# Altium schematic sheet Sheet_MotorDrive_v1.SchDoc(Sheet_MotorDrive_v1)
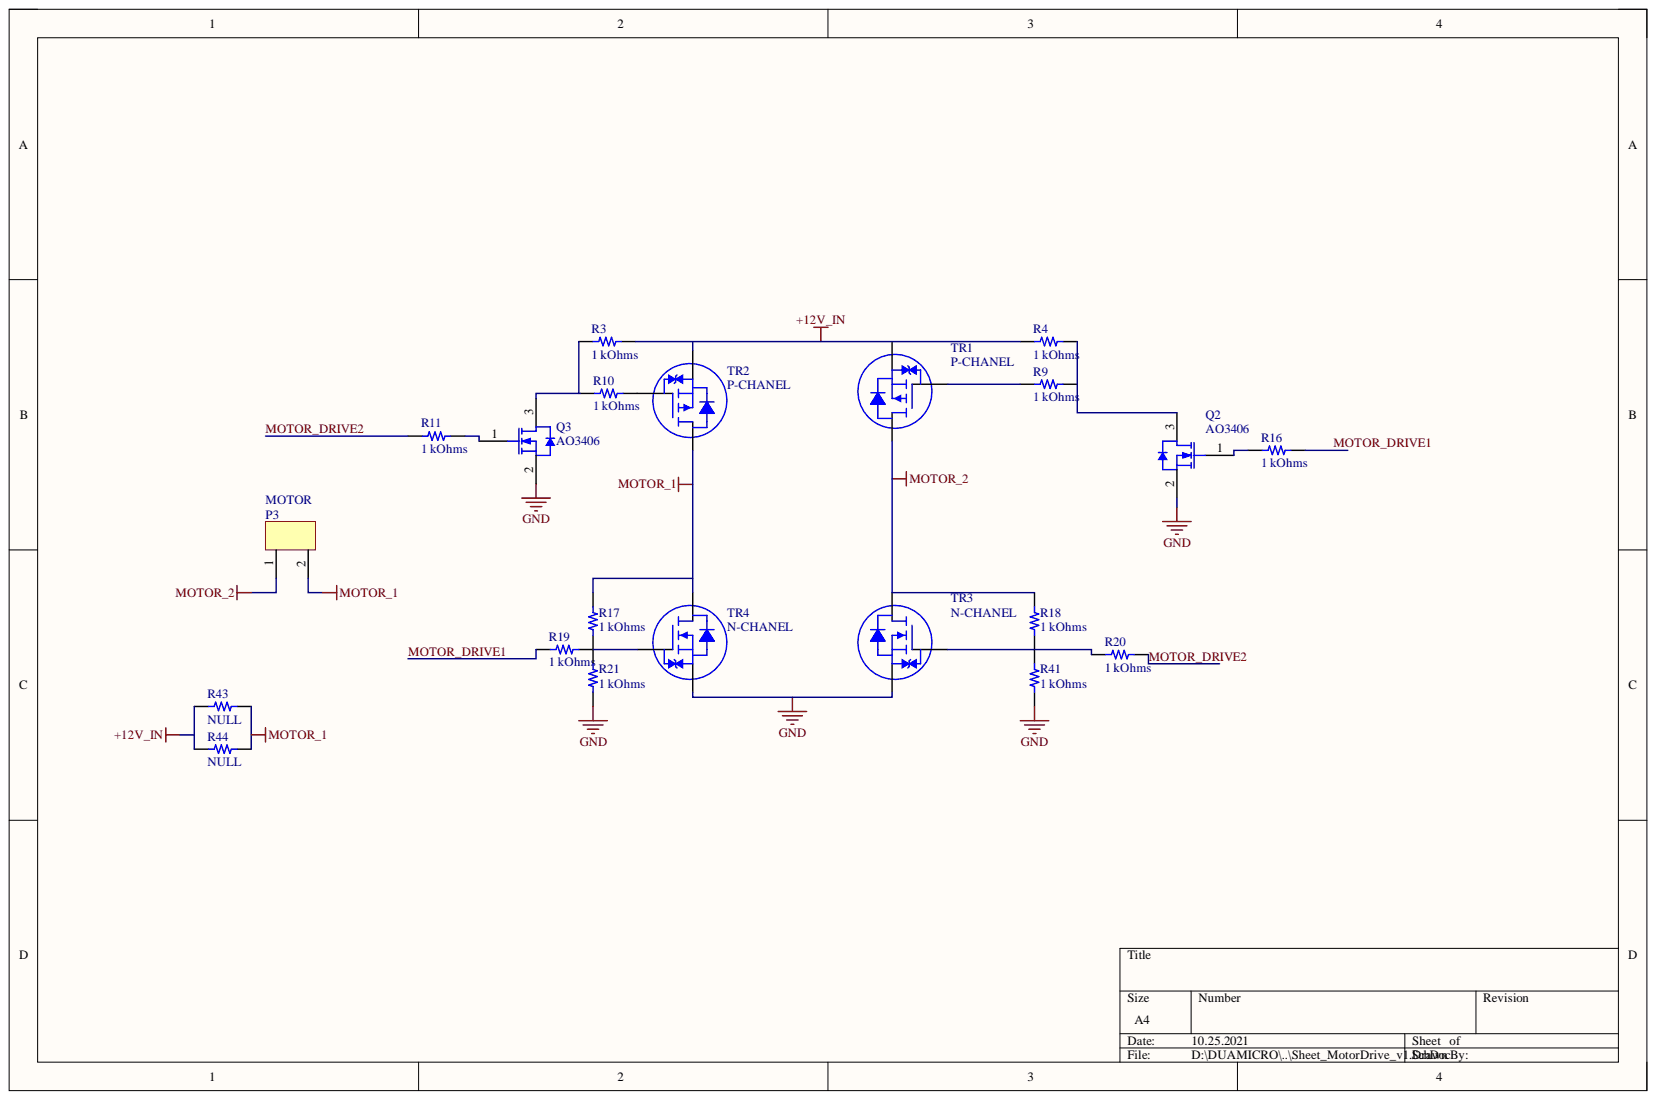
[diagram p0: Sheet_MotorDrive_v1.SchDoc]

## Parts
P3  MOTOR  [KLE2-3.50]
Q2  AO3406  [ON SEMI SOT-23-3]
Q3  AO3406  [ON SEMI SOT-23-3]
R3  1 kOhms  [RES 0603/1608]
R4  1 kOhms  [RES 0603/1608]
R9  1 kOhms  [RES 0603/1608]
R10  1 kOhms  [RES 0603/1608]
R11  1 kOhms  [RES 0603/1608]
R16  1 kOhms  [RES 0603/1608]
R17  1 kOhms  [RES 0603/1608]
R18  1 kOhms  [RES 0603/1608]
R19  1 kOhms  [RES 0603/1608]
R20  1 kOhms  [RES 0603/1608]
R21  1 kOhms  [RES 0603/1608]
R41  1 kOhms  [RES 0603/1608]
R43  NULL  [RES 0603/1608]
R44  NULL  [RES 0603/1608]
TR1  P-CHANEL  [D-PAK]
TR2  P-CHANEL  [D-PAK]
TR3  N-CHANEL  [D-PAK]
TR4  N-CHANEL  [D-PAK]

## Nets
+12V_IN: R3.2 R4.1 R43.1 R44.1 TR1.S TR2.S
GND: Q2.2 Q3.2 R21.1 R41.1 TR3.S TR4.S
MOTOR_1: P3.2 R17.2 R43.2 R44.2 TR2.D TR4.D
MOTOR_2: P3.1 R18.2 TR1.D TR3.D
MOTOR_DRIVE1: R16.2 R19.1
MOTOR_DRIVE2: R11.1 R20.2
NetQ2_1: Q2.1 R16.1
NetQ2_3: Q2.3 R4.2 R9.2
NetQ3_1: Q3.1 R11.2
NetQ3_3: Q3.3 R3.1 R10.1
NetR9_1: R9.1 TR1.G
NetR10_2: R10.2 TR2.G
NetR17_1: R17.1 R19.2 R21.2 TR4.G
NetR18_1: R18.1 R20.1 R41.2 TR3.G

## Library data of the parts
P3 = con2: KF2EDGR-Y-3.5-2P-1G; Component Kind: Standard; Library Name: SchlibTMS.SchLib
Q2 Q3 = MOSFET N-HANEL: HOTTECH MOSFET N-CHANNEL AO3406 SOT-23; Manufacturer: Alpha & Omega Semiconductor; Current - Continuous Drain: 3.6A(Ta); Drain to Source Voltage: 30V; Drive Voltage: 4.5V,10V; Gate Charge: 5nC@10V; Power Dissipation: 1.4W; Vgs(Max): 20V; Vgs(th): 2.5V@250uA; Input Capacitance: 210pF@15V; Component Kind: Standard; ComponentLink1Description: Digi Key; ComponentLink1URL: www.digikey.be/product-detail/en/alpha-omega-semiconductor-inc/AO3406/785-1005-1-ND/1855947; ComponentLink2Description: Datasheet; ComponentLink2URL: https://media.digikey.com/pdf/Data%20Sheets/Alpha%20&%20Omega/AO3406.pdf; FET Type: N - Channel; Operating Temperature: -55`C~150`C; Part Number: AO3406; Supplier: Digi - Key; Supplier Device Package: SOT-23-3; Technology: MOSFET; Library Name: ESKA_INT_ALL.IntLib
R3 R4 R9-R11 R16-R21 R41 = Resistor_1K_0603_5%: RES SMD 1K OHM 5% 1/16W 0603; Manufacturer: Stackpole Electronics; Part Number: RMCF0603JG1K00; PowerRating: 0.1W, 1/10W; TemperatureCoefficient: ±100ppm/°C; TemperatureRange: -55°C ~ 125°C; Component Kind: Standard; ComponentLink1Description: Datasheet; ComponentLink1URL: http://www.seielect.com/catalog/sei-packaging.pdf; ComponentLink2Description: Digi-Key Listing; ComponentLink2URL: https://www.digikey.com/product-detail/en/stackpole-electronics-inc/RMCF0603FT100K/RMCF0603FT100KCT-ND/1943118; Device Package: 0603 (1608 Metric); Price: $0.1000/min1; Supplier 1: Digi-Key; Supplier Part Number 1: RMCF0603JG1K00; Tolerance: ±5%; Value: 1 kOhms; Library Name: DualMicrolib.SchLib
R43 R44 = Resistor_NULL_0603: RES SMD NULL OHM JUMPER 1/10W 0603; Manufacturer: Panasonic Electronic Components; PowerRating: 0.1W, 1/10W; TemperatureRange: -55C ~ 155C; Component Kind: Standard; ComponentLink1Description: Datasheet; ComponentLink1URL: https://industrial.panasonic.com/ww/products/resistors/chip-resistors/general-purpose-chip-resistors/thick-film-chip-resistors/ERJ3GEY0R00V; ComponentLink2Description: Digi-Key Listing; ComponentLink2URL: https://www.digikey.com/product-detail/en/panasonic-electronic-components/ERJ-3GEY0R00V/P0.0GCT-ND/134711; Device Package: 0603 (1608 Metric); Price: $0.10000/min1; TemperatureCoefficient: -; Tolerance: Jumper; Value: NULL; Library Name: DualMicrolib.SchLib
TR1 TR2 = DMPH6050SK3-13: P-Channel 60 V 7.2A (Ta), 23.6A (Tc) 1.9W (Ta) Surface Mount TO-252-3; Manufacturer: Diodes Incorporated; Drain to Source Voltage (Vdss): 60 V; Drive Voltage (Max Rds On, Min Rds On): 4.5V, 10V; Gate Charge (Qg) (Max) @ Vgs: 25 nC @ 10 V; Rds On (Max) @ Id, Vgs: 50mOhm @ 7A, 10V; Vgs (Max): ±20V; Vgs(th) (Max) @ Id: 3V @ 250µA; Input Capacitance (Ciss) (Max) @ Vds: 1377 pF @ 30 V; Component Kind: Standard; ComponentLink1Description: Digi-Key; ComponentLink1URL: https://www.digikey.com/en/products/detail/diodes-incorporated/DMPH6050SK3-13/6010147; ComponentLink2Description: DataSheet; ComponentLink2URL: https://www.diodes.com/assets/Datasheets/DMPH6050SK3.pdf; Current - Continuous Drain (Id) @ 25°C: 7.2A (Ta), 23.6A (Tc); FET Type: P-Channel; Mounting Type: Surface Mount; Operating Temperature: -55°C ~ 175°C (TJ); Package / Case: TO-252-3, DPak (2 Leads + Tab), SC-63; Power Dissipation (Max): 1.9W (Ta); Supplier Device Package: TO-252-3; Technology: MOSFET (Metal Oxide); Library Name: DualMicrolib.SchLib
TR3 TR4 = FDD7N25LZ: N-Chanel UniFET MOSFET 250V 6.2A,550mohm; Component Kind: Standard; Library Name: DualMicrolib.SchLib
